# Altium schematic sheet UVSensor.SchDoc(UVSensor)
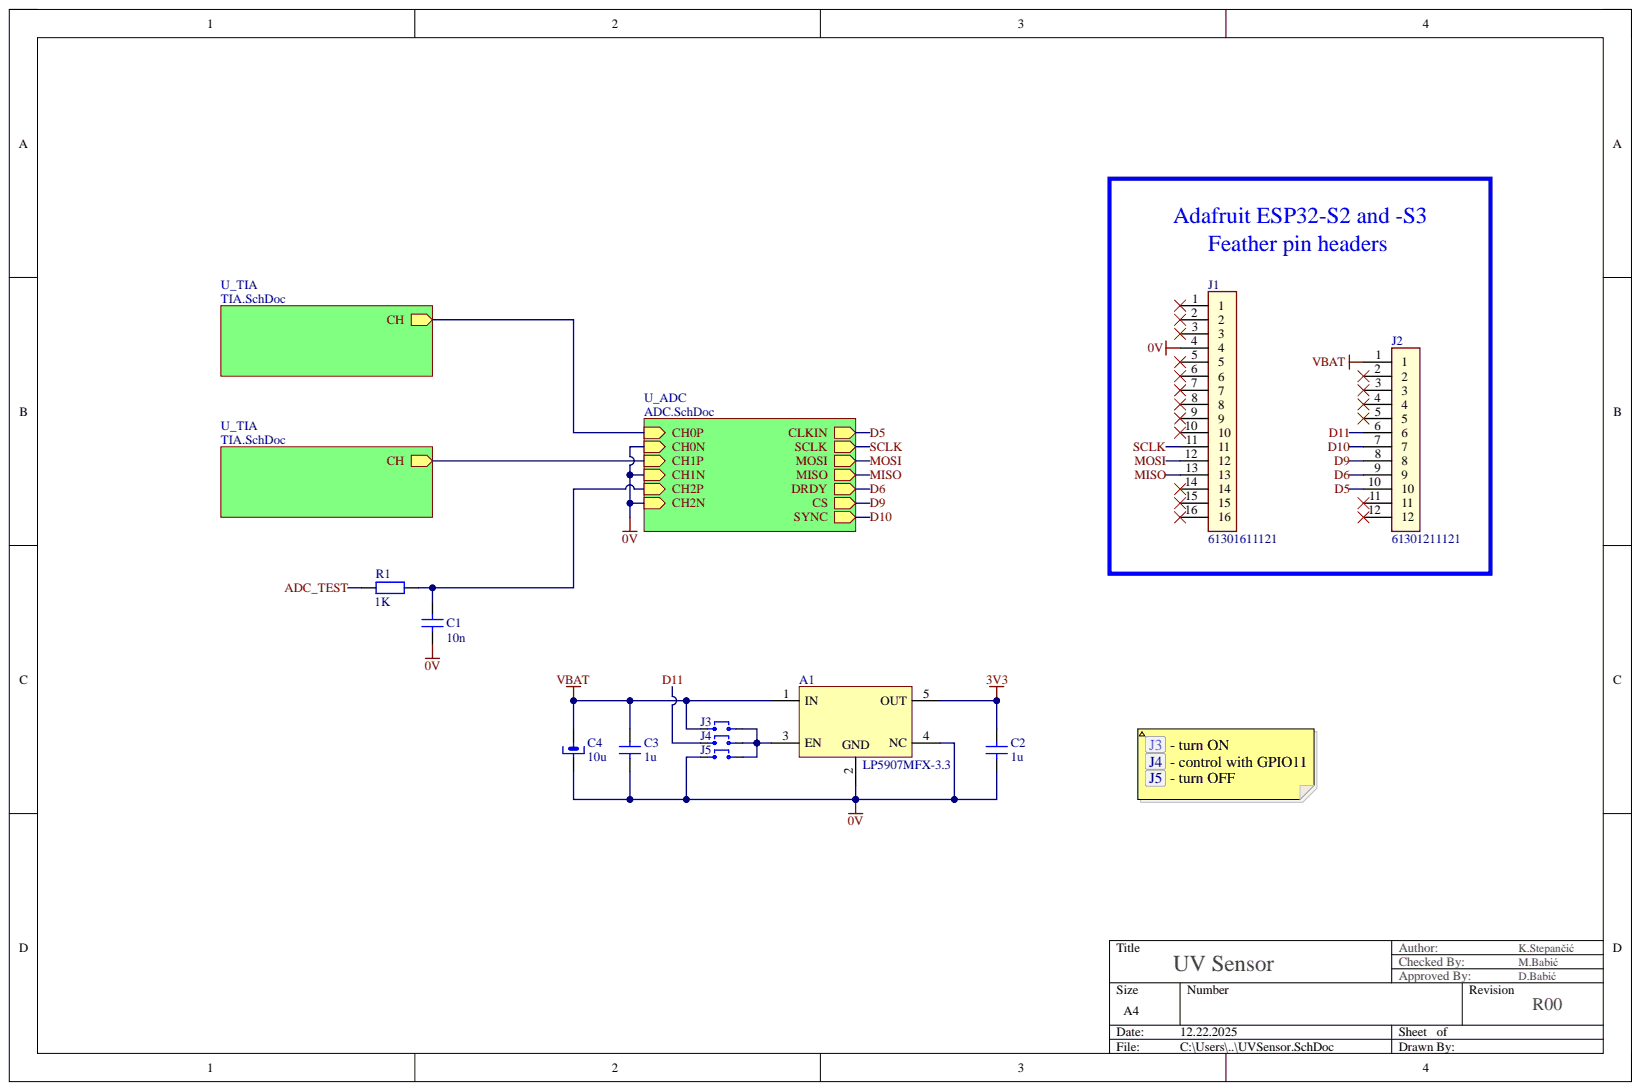
[diagram p0: UVSensor.SchDoc]

## Parts
A1  value LP5907MFX-3.3  [SOT95P280X145-5M]  (hidden comment: LP5907MFX-3.3/NOPB)
C1  10n  [CAPC2012X14M]
C2  1u  [CAPC2012X14M]
C3  1u  [CAPC2012X14M]
C4  value 10u  [CAPMP7343X31M]  (hidden comment: TC_LESR_10u_35V_20%)
J1  61301611121  [HDRV16W79P0X254_1X16_4064X254X874P]
J2  61301211121  [HDRV12W79P0X254_1X12_3048X254X874P]
J3  61300211121  [HDRV2W110P0X254_1X2_508X254X854P]  (value not drawn)
J4  61300211121  [HDRV2W110P0X254_1X2_508X254X854P]  (value not drawn)
J5  61300211121  [HDRV2W110P0X254_1X2_508X254X854P]  (value not drawn)
R1  1K  [RESC2112X05M]

## Nets
0V: A1.2 A1.4(NC) C1.2 C2.2 C3.2 C4.2 J1.4 J5.2
3V3: A1.5(OUT) C2.1
VBAT: A1.1(IN) C3.1 C4.1 J2.1 J3.2
ADC_TEST: R1.1
D5: J2.10
D6: J2.9
D9: J2.8
D10: J2.7
D11: J2.6 J4.2
MISO: J1.13
MOSI: J1.12
SCLK: J1.11
NetA1_3: A1.3(EN) J3.1 J4.1 J5.1
NetC1_1: C1.1 R1.2
NetJ1_1: J1.1
NetJ1_2: J1.2
NetJ1_3: J1.3
NetJ1_5: J1.5
NetJ1_6: J1.6
NetJ1_7: J1.7
NetJ1_8: J1.8
NetJ1_9: J1.9
NetJ1_10: J1.10
NetJ1_14: J1.14
NetJ1_15: J1.15
NetJ1_16: J1.16
NetJ2_2: J2.2
NetJ2_3: J2.3
NetJ2_4: J2.4
NetJ2_5: J2.5
NetJ2_11: J2.11
NetJ2_12: J2.12
CH:

## Library data of the parts
A1 = CMP-1291-0A: LDO Voltage Regulators 250mA Ultra-Lo Noise LDO Reg; Manufacturer: Texas Instruments; Voltage: 2.2V to 5.5V; Component Kind: Standard; DatasheetVersion: REVISED AUGUST 2019; Height: 1.45mm; HelpURL: \\SRV-PERUN\Repos\Comp\090_COTS\Components\Datasheets\Analog_integrated\TI\tlv713p.pdf; ManufacturerPartNumber: LP5907MFX-3.3/NOPB; MountingType: SMD; OperatingTemp: -40C to +125C; PackageType: SOT-23-5; PartStatus: Active; ROHS: Yes; ValueNumeric: LP5907MFX-3.3; Library Name: Koncar IET
C1 = CAPC2012X14M: C0805H103K5GACTU,   Multilayer Ceramic Capacitors MLCC - SMD/SMT 50V 0.01uF C0G 0805 10%; Component Kind: Standard; Library Name: SensorO3.SchLib
C2 C3 = CAPC2012X14M: C0805C105K5RACTU, Multilayer Ceramic Capacitors MLCC - SMD/SMT 50V 1uF X7R 0805 10%; Component Kind: Standard; Library Name: SensorO3.SchLib
C4 = CMP-0312-0D: Capacitor, Tantalum, 10uF, 35V, 20%, Low ESR, 250mΩ, Kemet; ManufacturerPartNumber: T495D106M035ATE250; ValueNumeric: 10000000; Component Kind: Standard; DatasheetVersion: 2/18/2016; HelpURL: \\srv-perun\Repos\Comp\090_COTS\Components\Datasheets\Capacitors\Kemet\KEM_T2009_T495.pdf; Manufacturer: Kemet; MountingType: SMD; OperatingTemp: -55 to 125; PackageType: 7343-31; PartStatus: Active; Tolerance: 20%; Voltage: 35V; Library Name: Koncar IET
J1 = 61301611121: Connector; Manufacturer_Name: Wurth Elektronik; Component Kind: Standard; Datasheet Link: https://www.we-online.com/catalog/datasheet/61301611121.pdf; Height: 8.74mm; Library Name: SensorO3.SchLib; Manufacturer_Part_Number: 61301611121; Mouser Part Number: 710-61301611121; Mouser Price/Stock: https://www.mouser.co.uk/ProductDetail/Wurth-Elektronik/61301611121?qs=PhR8RmCirEYq%2F9PEO1%2F%252B%252Bg%3D%3D
J2 = 61301211121: Connector; Manufacturer_Name: Wurth Elektronik; Component Kind: Standard; Datasheet Link: https://www.we-online.com/components/products/datasheet/61301211121.pdf; Height: 8.74mm; Library Name: SensorO3.SchLib; Manufacturer_Part_Number: 61301211121; Mouser Part Number: 710-61301211121; Mouser Price/Stock: https://www.mouser.co.uk/ProductDetail/Wurth-Elektronik/61301211121?qs=PhR8RmCirEbRCbngo1W%2F%2FA%3D%3D
J3-J5 = 61300211121: Connector; Manufacturer_Name: Wurth Elektronik; Component Kind: Standard; Datasheet Link: https://componentsearchengine.com/Datasheets/2/61300211121.pdf; Height: 8.54mm; Library Name: SensorO3.SchLib; Manufacturer_Part_Number: 61300211121; Mouser Part Number: 710-61300211121; Mouser Price/Stock: https://www.mouser.co.uk/ProductDetail/Wurth-Elektronik/61300211121?qs=t4813l51qx%252B1A5GYDQxPlw%3D%3D
R1 = RESC2112X05M: CRCW08051K00JNEC, Thick Film Resistors D12/CRCW0805 200 1K0 5% ET6 e3; Component Kind: Standard; Library Name: SensorO3.SchLib
